# Altium schematic sheet Power_Supply_and_Buttons.SchDoc(Power_Supply_and_Buttons)
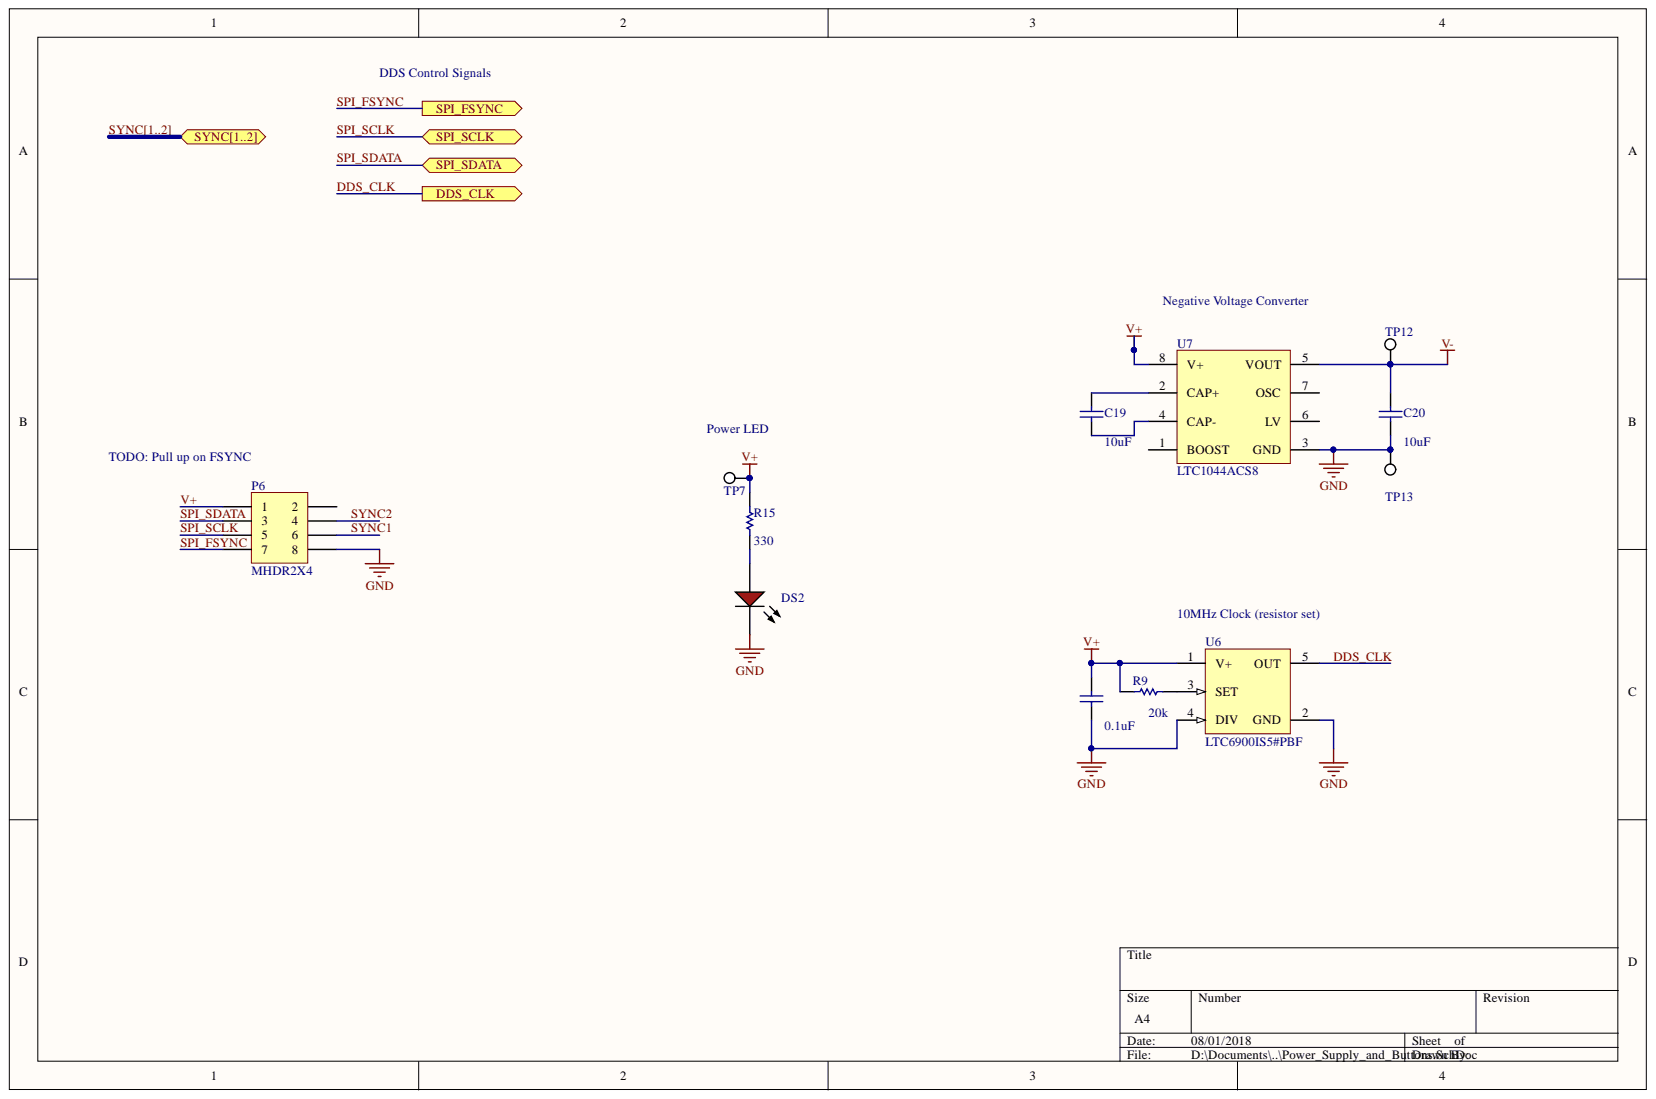
[diagram p0: Power_Supply_and_Buttons.SchDoc]

## Parts
C12  0.1uF  [1608[0603]]
C19  10uF  [1608[0603]]
C20  10uF  [1608[0603]]
DS2  TLMS1100-GS08  [VISH-TLMX1100_V]  (value not drawn)
P6  MHDR2X4  [MHDR2X4]
R9  20k  [1608[0603]]
R15  330  [1608[0603]]
TP7  TESTPOINT_WHITE  [TestPointVia]  (value not drawn)
TP12  TESTPOINT_WHITE  [TestPointVia]  (value not drawn)
TP13  TESTPOINT_WHITE  [TestPointVia]  (value not drawn)
U6  LTC6900IS5#PBF  [LT-TSOT-23-5_V]
U7  LTC1044ACS8  [LT-S8-8_M]

## Ports
DDS_CLK, SPI_FSYNC, SPI_SCLK, SPI_SDATA, SYNC1, SYNC2, SYNC[1..2]

## Nets
GND: C12.1 C20.1 DS2.2 P6.8 TP13.1 U6.2(GND) U6.4(DIV) U7.3(GND)
V-: C20.2 TP12.1 U7.5(VOUT)
DDS_CLK: U6.5(OUT) ; port DDS_CLK
SPI_FSYNC: P6.7 ; port SPI_FSYNC
SPI_SCLK: P6.5 ; port SPI_SCLK
SPI_SDATA: P6.3 ; port SPI_SDATA
SYNC1: P6.6 ; port SYNC[1..2]  [also labelled SYNC]
SYNC2: P6.4 ; port SYNC[1..2]  [also labelled SYNC]
V+: C12.2 P6.1 R9.1 R15.2 TP7.1 U6.1(V+) U7.8(V+)
NetC19_1: C19.1 U7.4(CAP-)
NetC19_2: C19.2 U7.2(CAP+)
NetDS2_1: DS2.1 R15.1
NetR9_2: R9.2 U6.3(SET)

Not connected: P6 2; U7 1(BOOST) 6(LV) 7(OSC)

## Library data of the parts
C12 C19 C20 = Cap Semi: Capacitor (Semiconductor SIM Model); Simulation: CAP; Signal Integrity: Cap Semi; Published: 8-Jun-2000; PackageReference: C1206; PackageDescription: Chip Capacitor; Library Name: Miscellaneous Devices.IntLib; LatestRevisionNote: IPC-7351 Footprint Added.; LatestRevisionDate: 29-May-2009; Component Kind: Standard; Publisher: Altium Limited
  C12: Value: 0.1uF
  C19 C20: Value: 10uF
DS2 = CMP-1666-00027-1: LED, RED, 2.1 V, -40 to 100 degC, 2-Pin SMD (0603), RoHS, Tape and Reel; Voltage Rating (DC): 50 V; Type (Capacitors): C0G; Tolerance: 5%; Resistance: 100000000000 Ohm; Capacitance: 12 pF; Width: 0.8 mm; Voltage Rating: 50 V; RoHSCompliant: True; REACH SVHC: No SVHC; Radiation Hardening: No; Pins: 2; Packaging: Tape and Reel; PackageReference: TLMX1100; Package Quantity: 4000; PackageDescription: 2-Pin Surface Mount Device, Body 1.6 x 0.8 mm; Mount: Surface Mount; Min Operating Temperature: -55degC; Max Operating Temperature: 125degC; Library Name: Altium Content Vault; Length: 1.6 mm; Height: 0.8 mm; DatasheetVersion: Rev. 2.7, 03/2016; ComponentLink2URL: http://www.vishay.com/docs/83173/tlmb1100.pdf; ComponentLink2Description: Datasheet; ComponentLink1URL: http://www.vishay.com/; ComponentLink1Description: Manufacturer URL; Component Kind: Standard; Case Code (Metric): 1608; Case Code (Imperial): 0603; Case/Package: 0603
P6 = MHDR2X4: Header, 4-Pin, Dual row; Signal Integrity: Connector; Library Name: Miscellaneous Connectors.IntLib; LatestRevisionNote: Re-released for DXP Platform.; LatestRevisionDate: 17-Jul-2002; Component Kind: Standard; Publisher: Altium Limited
R9 R15 = Res1: Resistor; Simulation: RESISTOR; Signal Integrity: Res; Published: 8-Jun-2000; PackageReference: AXIAL-0.3; PackageDescription: Axial Device, Thru-Hole; 2 Leads; 0.3 in Pin Spacing; Library Name: Miscellaneous Devices.IntLib; LatestRevisionNote: Re-released for DXP Platform.; LatestRevisionDate: 17-Jul-2002; Component Kind: Standard; Publisher: Altium Limited
  R9: Value: 20k
  R15: Value: 330
U6 = CMP-2000-06612-1: Low Power, Resistor Set Oscillator, 1 KHz to 20 MHz, 2.7 to 5.5 V, -40 to 85 degC, 5-Pin SOT-23 (S5), Pb-Free; Manufacturer: Linear Technology; SVHC: NoSVHC(19-Dec-2012); Supply Voltage Range: 2.7Vto5.5V; Supply Voltage Min: 2.7V; Supply Voltage Max: 5.5V; Operating Frequency Max: 20MHz; No. of Pins: 5; Clock External Input: No; Base Number: 6900; Termination Type: SMD; Package Reference: TSOT23-5; Package Description: 5-Pin Small Outline Transistor, Body 2.9 x 1.625 mm, Pitch 0.95 mm; Package / Case: SOT-23; Operating Temperature Range: -40°Cto+85°C; Operating Temperature Min: -40°C; Operating Temperature Max: 85°C; MSL: MSL1-Unlimited; Mounting Technology: SurfaceMount; Manufacturer URL: http://www.linear.com/; Library Name: Altium Content Vault; Frequency: 20MHz; Digital IC Case Style: SOT-23; Datasheet Version: Rev. A, 07/2009; Datasheet URL: http://cds.linear.com/docs/en/datasheet/6900fa.pdf; Component Kind: Standard
U7 = CMP-0475-00491-1: 12 V CMOS Voltage Converter, 8-pin SOIC (S8-8), 0 to 70 degC; Manufacturer: Linear Technology; Voutput: 2Vin, -Vin, 0.5Vin; Vin Min(V): 1.5; Vin Max(V): 12; Max Iout(mA): 20; Isupply(mA): 0.2; Frequency(kHz): 5; RoHS: FALSE; PartNumber: LTC1044ACS8; PackageVersion: Rev. G, 03/2012; PackageReference: S8-8; PackageDescription: 8-Pin Plastic Small Outline (Narrow .150 Inch), Body 4.9 x 3.9 mm, Pitch 1.27 mm; Mounting Technology: Surface Mount; Library Name: Altium Content Vault; DatasheetVersion: Rev. 0; ComponentLink3URL: http://cds.linear.com/docs/en/packaging/05081610_G_SO8.pdf; ComponentLink3Description: Package Specification; ComponentLink2URL: http://cds.linear.com/docs/en/datasheet/lt1044a.pdf; ComponentLink2Description: Datasheet; ComponentLink1URL: http://www.linear.com/; ComponentLink1Description: Manufacturer URL; Component Kind: Standard
TP7 TP12 TP13 = TESTPOINT_WHITE: Component Kind: Standard
